# Altium schematic sheet indicator.SchDoc
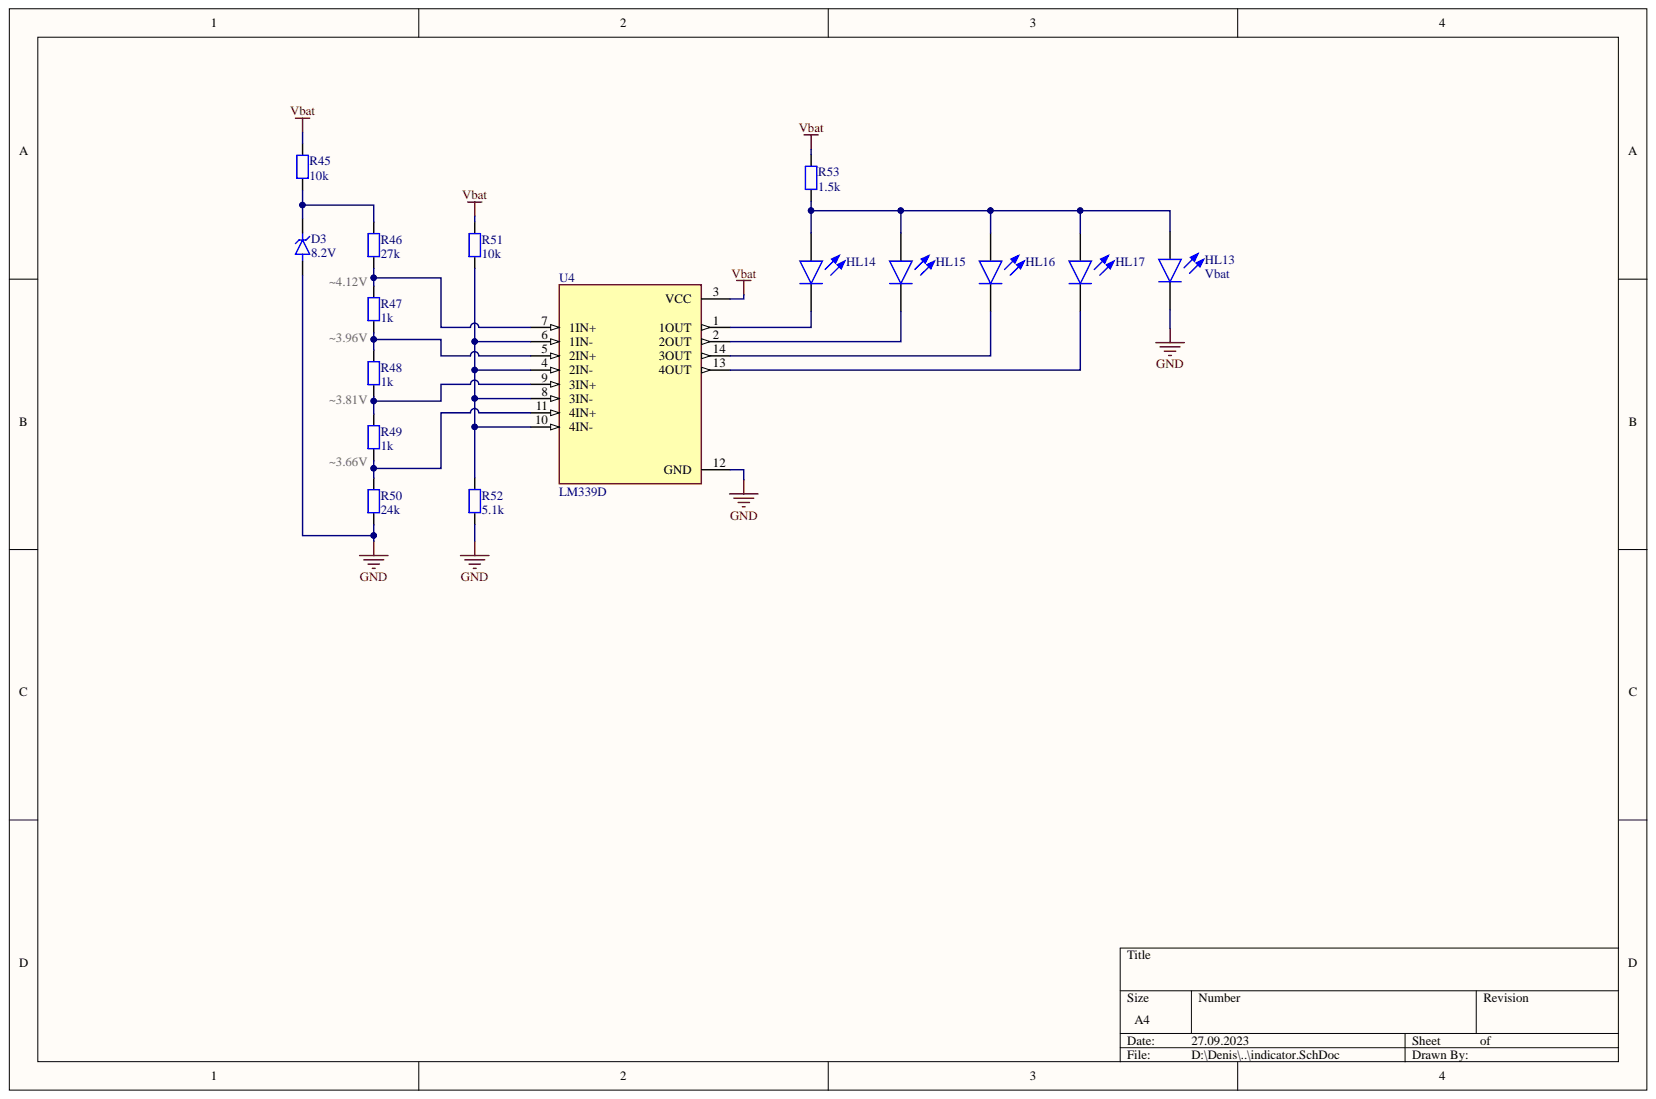
[diagram p0: indicator.SchDoc]

## Parts
D3  8.2V  [BZV55C8V2]
HL13  Vbat  [0603_LED_RED]
HL14  =Value  [0603_LED_GREEN]
HL15  =Value  [0603_LED_GREEN]
HL16  =Value  [0603_LED_GREEN]
HL17  =Value  [0603_LED_GREEN]
R45  10k  [res0603]
R46  27k  [res0603]
R47  1k  [res0603]
R48  1k  [res0603]
R49  1k  [res0603]
R50  24k  [res0603]
R51  10k  [res0603]
R52  5.1k  [res0603]
R53  1.5k  [res0603]
U4  LM339D  [LM339D]

## Nets
GND: D3.A HL13.1 R50.1 R52.1 U4.12(GND)
Vbat: R45.2 R51.2 R53.2 U4.3(VCC)
NetD3_C: D3.C R45.1 R46.2
NetHL13_2: HL13.2 HL14.2 HL15.2 HL16.2 HL17.2 R53.1
NetHL14_1: HL14.1 U4.1(1OUT)
NetHL15_1: HL15.1 U4.2(2OUT)
NetHL16_1: HL16.1 U4.14(3OUT)
NetHL17_1: HL17.1 U4.13(4OUT)
NetR46_1: R46.1 R47.2 U4.7(1IN+)
NetR47_1: R47.1 R48.2 U4.5(2IN+)
NetR48_1: R48.1 R49.2 U4.9(3IN+)
NetR49_1: R49.1 R50.2 U4.11(4IN+)
NetR51_1: R51.1 R52.2 U4.4(2IN-) U4.6(1IN-) U4.8(3IN-) U4.10(4IN-)

## Library data of the parts
D3 = BZV55C8V2: Component Kind: Standard; Library Name: Asterisk.SchLib
HL13-HL17 = LED: LED smd; Component Kind: Standard; Library Name: Asterisk.SchLib
R45-R53 = Resistor SMD: Component Kind: Standard; Library Name: GKirik.SchLib
U4 = LM339D: Component Kind: Standard; Library Name: Asterisk.SchLib
